# Altium schematic sheet System.SchDoc
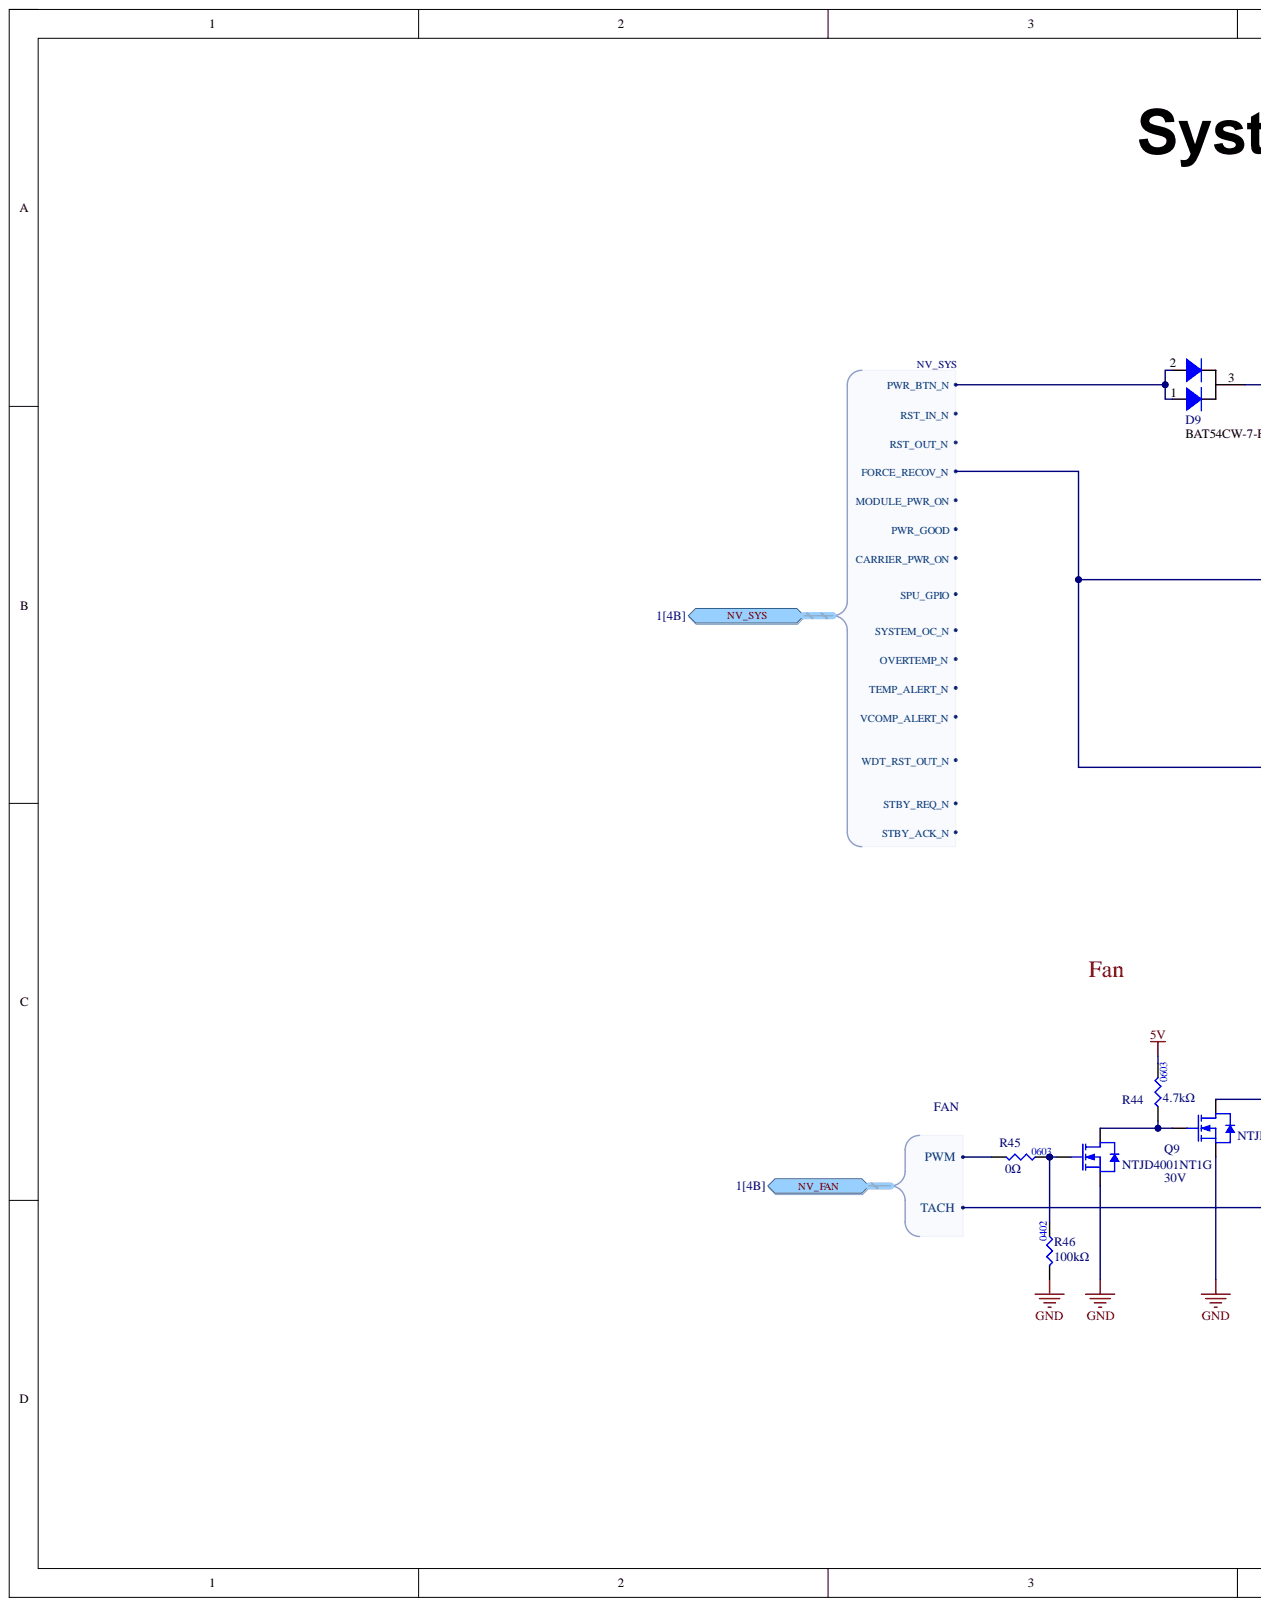
[diagram p0: System.SchDoc, left]
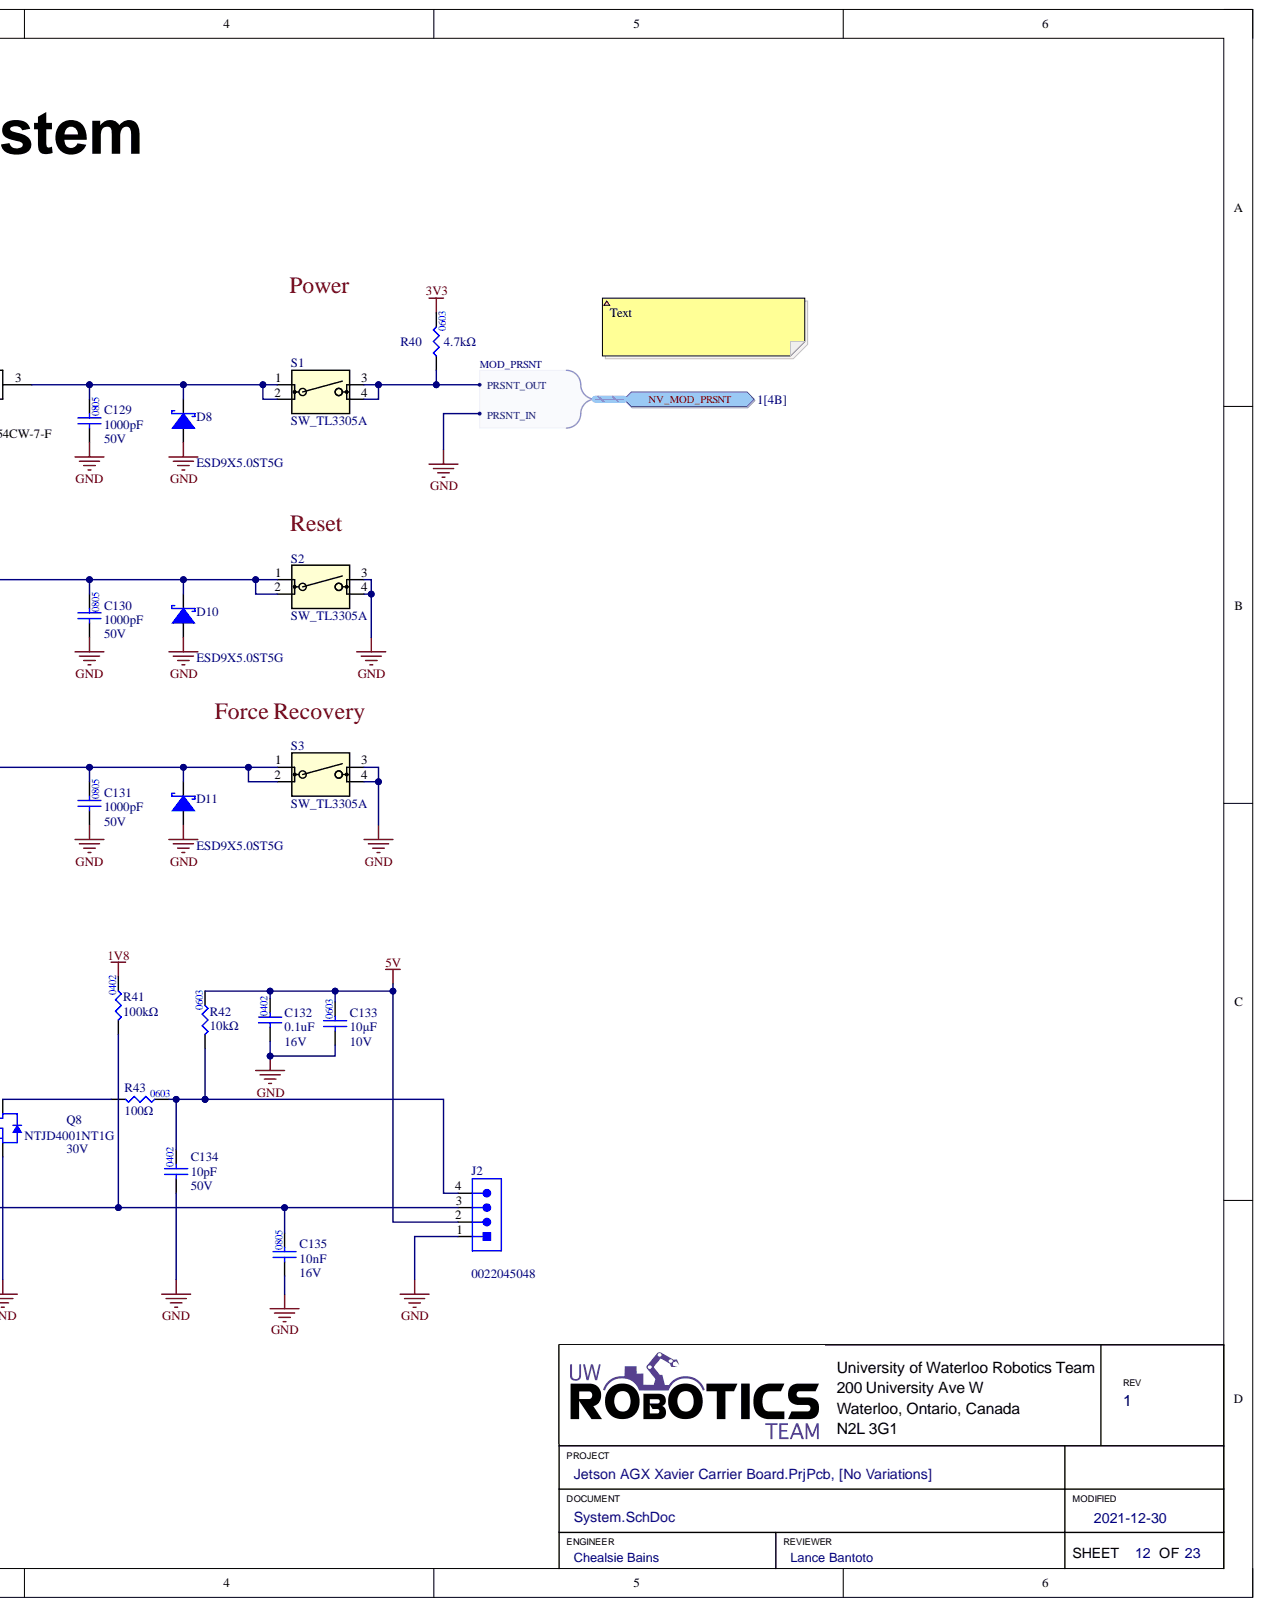
[diagram p1: System.SchDoc, right]

## Parts
C129  value 1000pF  Package/Footprint: 0805  Voltage Rating: 50V  [CAP2012]  (hidden comment: CAP_1000pF_50V_0805)
C130  value 1000pF  Package/Footprint: 0805  Voltage Rating: 50V  [CAP2012]  (hidden comment: CAP_1000pF_50V_0805)
C131  value 1000pF  Package/Footprint: 0805  Voltage Rating: 50V  [CAP2012]  (hidden comment: CAP_1000pF_50V_0805)
C132  Capacitance: 0.1uF  Rated DC Voltage (URdc): 16V  Size Code: 0402  [CAP0402]  (hidden comment: CAP_0.1uF_16V_X7R_0402)
C133  value 10μF  Voltage Rating: 10V  [CAP0603]  (hidden comment: CAP_10uF_10V_0603)
C134  Capacitance: 10pF  Package: 0402  Voltage: 50V  [CAP0402]  (hidden comment: CAP_10pF_50V_0402)
C135  value 10nF  Voltage Rating: 16V  [CAP2012]  (hidden comment: CAP_10nF_16V_0805)
D8  Manufacturer Part Number 1: ESD9X5.0ST5G  [SOD-923]  (hidden comment: TVS_DIODE_UNIDIR_5V)
D9  BAT54CW-7-F  [SOT323]
D10  Manufacturer Part Number 1: ESD9X5.0ST5G  [SOD-923]  (hidden comment: TVS_DIODE_UNIDIR_5V)
D11  Manufacturer Part Number 1: ESD9X5.0ST5G  [SOD-923]  (hidden comment: TVS_DIODE_UNIDIR_5V)
J2  Manufacturer Part Number: 0022045048  (hidden comment: CON_4POS)
Q8  DS Breakdown Voltage-Min: 30V  Manufacturer Part Number 1: NTJD4001NT1G  (hidden comment: NMOS_30V_0.25A)
Q9  Manufacturer Part Number 1: NTJD4001NT1G  (hidden comment: NMOS_30V_0.25A)
R40  value 4.7kΩ  [RES0603]  (hidden comment: RES_4.7kΩ_0603)
R41  value 100kΩ  Package/Footprint: 0402  [RES0402]  (hidden comment: RES_100kΩ_0402_5%)
R42  value 10kΩ  Package/Footprint: 0603  [RES0603]  (hidden comment: RES_10kΩ_0603_5%)
R43  value 100Ω  [RES0603]  (hidden comment: RES_100Ω_0603)
R44  value 4.7kΩ  [RES0603]  (hidden comment: RES_4.7kΩ_0603)
R45  value 0Ω  Package/Footprint: 0603  [RES0603]  (hidden comment: RES_0Ω_0603)
R46  value 100kΩ  Package/Footprint: 0402  [RES0402]  (hidden comment: RES_100kΩ_0402_5%)
S1  SW_TL3305A  [SW_TL3305AF260QG]
S2  SW_TL3305A  [SW_TL3305AF260QG]
S3  SW_TL3305A  [SW_TL3305AF260QG]

## Ports
NV_FAN, NV_FAN.PWM, NV_FAN.TACH, NV_MOD_PRSNT, NV_MOD_PRSNT.PRSNT_IN, NV_MOD_PRSNT.PRSNT_OUT, NV_SYS, NV_SYS.CARRIER_PWR_ON, NV_SYS.FORCE_RECOV_N, NV_SYS.MODULE_PWR_ON, NV_SYS.OVERTEMP_N, NV_SYS.PWR_BTN_N, NV_SYS.PWR_GOOD, NV_SYS.RST_IN_N, NV_SYS.RST_OUT_N, NV_SYS.SPU_GPIO, NV_SYS.STBY_ACK_N, NV_SYS.STBY_REQ_N, NV_SYS.SYSTEM_OC_N, NV_SYS.TEMP_ALERT_N, NV_SYS.VCOMP_ALERT_N, NV_SYS.WDT_RST_OUT_N

## Nets
1V8: R41.2
3V3: R40.2
5V: C132.2 C133.2 J2.2 R42.2 R44.2
GND: C129.1 C130.1 C131.1 C132.1 C133.1 C134.1 C135.1 D8.1 D10.1 D11.1 J2.1 Q8.2 Q9.2 R46.1 S2.3 S2.4 S3.3 S3.4 ; port NV_MOD_PRSNT
NV_MOD_PRSNT: R40.1 S1.3 S1.4 ; port NV_MOD_PRSNT
NetC129_2: C129.2 D8.2 S1.1 S1.2
NetC130_2: C130.2 C131.2 D10.2 D11.2 S2.1 S2.2 S3.1 S3.2 ; port NV_SYS
NetC134_2: C134.2 J2.4 R42.1 R43.2
NetC135_2: C135.2 J2.3 R41.1 ; port NV_FAN
NetD9_1: D9.1 D9.2 ; port NV_SYS
NetQ8_1: Q8.1 Q9.3 R44.1
NetQ8_3: Q8.3 R43.1
NetQ9_1: Q9.1 R45.2 R46.2
NetR45_1: R45.1 ; port NV_FAN
N00001: ; port NV_SYS
N00002: ; port NV_SYS
N00003: ; port NV_SYS
N00004: ; port NV_SYS
N00005: ; port NV_SYS
N00006: ; port NV_SYS
N00007: ; port NV_SYS
N00008: ; port NV_SYS
N00009: ; port NV_SYS
N00010: ; port NV_SYS
N00011: ; port NV_SYS
N00012: ; port NV_SYS
N00013: ; port NV_SYS

Not connected: D9 3

## Library data of the parts
C129-C131 = CAP_1000pF_50V_0805: CAP CER 1000pF 50V X7R 0805; Manufacturer 1: Samsung; Manufacturer Part Number 1: CL21B102KBANNNC; Component Kind: Standard; Dielectric: X7R; Mounting Type: SMD; Supplier 1: Digi-Key; Supplier Part Number 1: 1276-1020-1-ND; Library Name: UWRT_Schematic_Library_2020.SchLib
C132 = CAP_0.1uF_16V_X7R_0402: Ceramic Capacitor, Ceramic; Manufacturer: Taiyo Yuden; Manufacturer Part Number: LMK212AC6106MD-T; Multilayer: Yes; Negative Tolerance: 10%; Temperature Characteristics Code: X7R; Capacitor Type: Ceramic; Category: Ceramic Capacitors; Component Kind: Standard; ComponentLink1Description: https://datasheet.ciiva.com:443/pdfs/VipMasterIC/IC/TAIY/TAIY-S-A0009099504/TAIY-S-A0009099407-1.pdf?src-supplier=IHS+Markit; ComponentLink1URL: https://datasheet.ciiva.com:443/pdfs/VipMasterIC/IC/TAIY/TAIY-S-A0009099504/TAIY-S-A0009099407-1.pdf?src-supplier=IHS+Markit; ComponentLink2Description: https://ds.yuden.co.jp/TYCOMPAS/ut/detail?pn=LMK212AC6106MD-T &amp;u=M&src-supplier=Digi-Key; ComponentLink2URL: https://ds.yuden.co.jp/TYCOMPAS/ut/detail?pn=LMK212AC6106MD-T &amp;u=M&src-supplier=Digi-Key; ComponentLink3Description: https://datasheet.ciiva.com:443/pdfs/VipMasterIC/IC/WATC/WATC-S-A0009550342/WATC-S-A0009550342-1.pdf?src-supplier=IHS+Markit; ComponentLink3URL: https://datasheet.ciiva.com:443/pdfs/VipMasterIC/IC/WATC/WATC-S-A0009550342/WATC-S-A0009550342-1.pdf?src-supplier=IHS+Markit; ComponentLink4Description: http://www.farnell.com/datasheets/2340522.pdf?src-supplier=Farnell; ComponentLink4URL: http://www.farnell.com/datasheets/2340522.pdf?src-supplier=Farnell; ComponentLink5Description: http://components.arrow.com/part/dataview/0323_00654690?src-supplier=Arrow+Europe; ComponentLink5URL: http://components.arrow.com/part/dataview/0323_00654690?src-supplier=Arrow+Europe; ComponentLink6Description: https://www.verical.com/server-webapp/html/getDatasheet?partID=415228&src-supplier=Verical; ComponentLink6URL: https://www.verical.com/server-webapp/html/getDatasheet?partID=415228&src-supplier=Verical; ComponentLink7Description: http://www.passivecomponent.com/indexsea/0402B104K160CT-IN.pdf?src-supplier=Digi-Key; ComponentLink7URL: http://www.passivecomponent.com/indexsea/0402B104K160CT-IN.pdf?src-supplier=Digi-Key; ComponentLink8Description: http://www.passivecomponent.com/wp-content/uploads/2018/10/MLCC.pdf?src-supplier=Digi-Key; ComponentLink8URL: http://www.passivecomponent.com/wp-content/uploads/2018/10/MLCC.pdf?src-supplier=Digi-Key; ComponentLink9Description: http://www.farnell.com/datasheets/2340522.pdf?src-supplier=Element14; ComponentLink9URL: http://www.farnell.com/datasheets/2340522.pdf?src-supplier=Element14; ComponentLink10Description: http://www.farnell.com/datasheets/2340522.pdf?src-supplier=Newark; ComponentLink10URL: http://www.farnell.com/datasheets/2340522.pdf?src-supplier=Newark; ComponentLink11Description: https://datasheet.ciiva.com:443/pdfs/VipMasterIC/IC/TDKC/TDKC-S-A0007785269/TDKC-S-A0007785269-1.pdf?src-supplier=IHS+Markit; ComponentLink11URL: https://datasheet.ciiva.com:443/pdfs/VipMasterIC/IC/TDKC/TDKC-S-A0007785269/TDKC-S-A0007785269-1.pdf?src-supplier=IHS+Markit; ComponentLink12Description: https://product.tdk.com/info/en/catalog/spec/mlccspec_commercial_soft_en.pdf?src-supplier=Digi-Key; ComponentLink12URL: https://product.tdk.com/info/en/catalog/spec/mlccspec_commercial_soft_en.pdf?src-supplier=Digi-Key; Dielectric Material: Ceramic; Height: 0.5mm; JESD-609 Code: e3; Length: 1mm; Max Operating Temperature: 125°C; Min Operating Temperature: -55°C; Mount: Surface Mount; Number of Pins: 2; Package Shape: Rectangular; Package Style: SMTMeter; Packaging: Tape and Reel; Positive Tolerance: 10%; Pricing 1: 1=0.29000, 10=0.20100, 50=0.12060, 100=0.10180, 500=0.06966, 1000=0.06163 (USD); Pricing 2: 1=0.10000, 10=0.02800, 50=0.01500, 100=0.01220, 500=0.00876, 1000=0.00688, 2500=0.00625, 5000=0.00575 (USD); Pricing 3: 1=0.20000, 10=0.14200, 50=0.08080, 100=0.06700, 500=0.04696, 1000=0.03888, 2500=0.03619, 5000=0.03311 (USD); REACH SVHC: Yes; RoHS: Yes; RoHS Compliant: Yes; Stock 1: 703; Stock 2: 20327886; Stock 3: 3627; Supplier 1: Digi-Key; Supplier 2: Digi-Key; Supplier 3: Digi-Key; Supplier Part Number 1: 587-6337-1-ND; Supplier Part Number 2: 1292-1630-1-ND; Supplier Part Number 3: 445-173645-1-ND; Terminal Finish: Nickel; Termination: Wraparound; Width: 0.5mm; Library Name: UWRT_Schematic_Library_2020.SchLib
C133 = CAP_10uF_10V_0603: CAP CER 10UF 10V X5R 0603; Manufacturer 1: Yageo; Manufacturer Part Number 1: CC0603MRX5R6BB106; Component Kind: Standard; Dielectric: X5R; Supplier 1: Digi-Key; Supplier Part Number 1: 311-1817-1-ND; Library Name: UWRT_Schematic_Library_2020.SchLib
C134 = CAP_10pF_50V_0402: Ceramic Capacitor, Multilayer, Ceramic, 50V, 1% +Tol, 1% -Tol, C0G, 30ppm/Cel TC, 0.00001uF, Surface Mount, 0402; Component Kind: Standard; Library Name: UWRT_Schematic_Library_2020.SchLib
C135 = CAP_10nF_16V_0805: CAP CER 10000PF 16V X7R 0805; Manufacturer 1: Yageo; Manufacturer Part Number 1: AC0805KRX7R7BB103; Component Kind: Standard; Supplier 1: Digi-Key; Supplier Part Number 1: 311-3161-1-ND; Library Name: UWRT_Schematic_Library_2020.SchLib
D8 D10 D11 = TVS_DIODE_UNIDIR_5V: TVS DIODE 5VWM 12.3VC SOD923; Manufacturer 1: ON Semiconductor; Clamping Voltage-Max: 12.3V; Min Breakdown Voltage: 6.2V; Component Kind: Standard; Supplier 1: Digi-Key; Supplier Part Number 1: ESD9X5.0ST5GOSCT-ND; Library Name: UWRT_Schematic_Library_2020.SchLib
D9 = DIODE_30V_SOT323: Diode Array 1 Pair Common Cathode Schottky 30 V 200mA (DC) Surface Mount SC-70, SOT-323; Built_By: EMA_Anthony; SourceLibrary: Diodes_Inc_2018-12-15; Type: DEV; Vendor: Diodes Inc; Component Kind: Standard; Manufacturer_Part_Number: BAT54CW-7-F; RefDes: RefDes; Copyright: Copyright (C) 2016 Accelerated Designs. All rights reserved; Library Name: UWRT_Schematic_Library_2020.SchLib
J2 = CON_4POS: CONN HEADER VERT 4POS 2.54MM; Manufacturer: Molex; Category: Headers and Wire Housings; Component Kind: Standard; ComponentLink1Description: https://datasheet.ciiva.com/6839/022045032-sd-6839882.pdf?src-supplier=Digi-Key; ComponentLink1URL: https://datasheet.ciiva.com/6839/022045032-sd-6839882.pdf?src-supplier=Digi-Key; Pricing 1: 16000=0.12858 (USD); RoHS: Yes; Supplier 1: Digi-Key; Supplier Part Number 1: 0022045048-ND; Library Name: UWRT_Schematic_Library_2020.SchLib
Q8 Q9 = NMOS_30V_0.25A: MOSFET 2N-CH 30V 0.25A SOT-363; Manufacturer 1: ON Semiconductor; Component Kind: Standard; Supplier 1: Digi-Key; Supplier Part Number 1: NTJD4001NT1GOSCT-ND; Library Name: UWRT_Schematic_Library_2020.SchLib
  Q9: DS Breakdown Voltage-Min: 30V
R40 R44 = RES_4.7kΩ_0603_5%: RES 4.7K OHM 5% 1/10W 0603; Manufacturer 1: Yageo; Manufacturer Part Number 1: RC0603JR-074K7L; Component Kind: Standard; Supplier 1: Digi-Key; Supplier Part Number 1: 311-4.7KGRCT-ND; Library Name: UWRT_Schematic_Library_2020.SchLib
R41 R46 = RES_100kΩ_0402_5%: RES SMD 100K OHM 5% 1/10W 0402; Manufacturer 1: Panasonic Electronic Components; Manufacturer Part Number 1: ERJ-2GEJ104X; Power: 0.1W, 1/10W; Component Kind: Standard; Mounting Type: SMD; Supplier 1: Digi-Key; Supplier Part Number 1: P100KJCT-ND; Tolerance: 5%; Library Name: UWRT_Schematic_Library_2020.SchLib
R42 = RES_10kΩ_0603_5%: RES 10K OHM 5% 1/10W 0603; Manufacturer 1: Yageo; Manufacturer Part Number 1: RC0603JR-0710KL; Component Kind: Standard; Mounting Type: SMD; Supplier 1: Digi-Key; Supplier Part Number 1: 311-10KGRCT-ND; Library Name: UWRT_Schematic_Library_2020.SchLib
R43 = RES_100Ω_0603_1%: RES 100 OHM 1% 1/10W 0603; Manufacturer 1: Yageo; Manufacturer Part Number 1: RC0603FR-07100RL; Component Kind: Standard; Supplier 1: Digi-Key; Supplier Part Number 1: 311-100HRCT-ND; Library Name: UWRT_Schematic_Library_2020.SchLib
R45 = RES_0Ω_0603: RES 0 OHM JUMPER 1/10W 0603; Manufacturer 1: Yageo; Manufacturer Part Number 1: RC0603JR-070RL; Component Kind: Standard; Mounting Type: SMD; Supplier 1: Digi-Key; Supplier Part Number 1: 311-0.0GRCT-ND; Library Name: UWRT_Schematic_Library_2020.SchLib
S1-S3 = SW_TL3305A: TL3305A Series SPST 12 V 4.5 x 4.5 mm 260 gf Top Actuated SMT Tactile Switch; Manufacturer Part Number: TL3305AF260QG; Component Kind: Standard; Library Name: UWRT_Schematic_Library_2020.SchLib
